# Altium schematic sheet 5V-REG.SchDoc
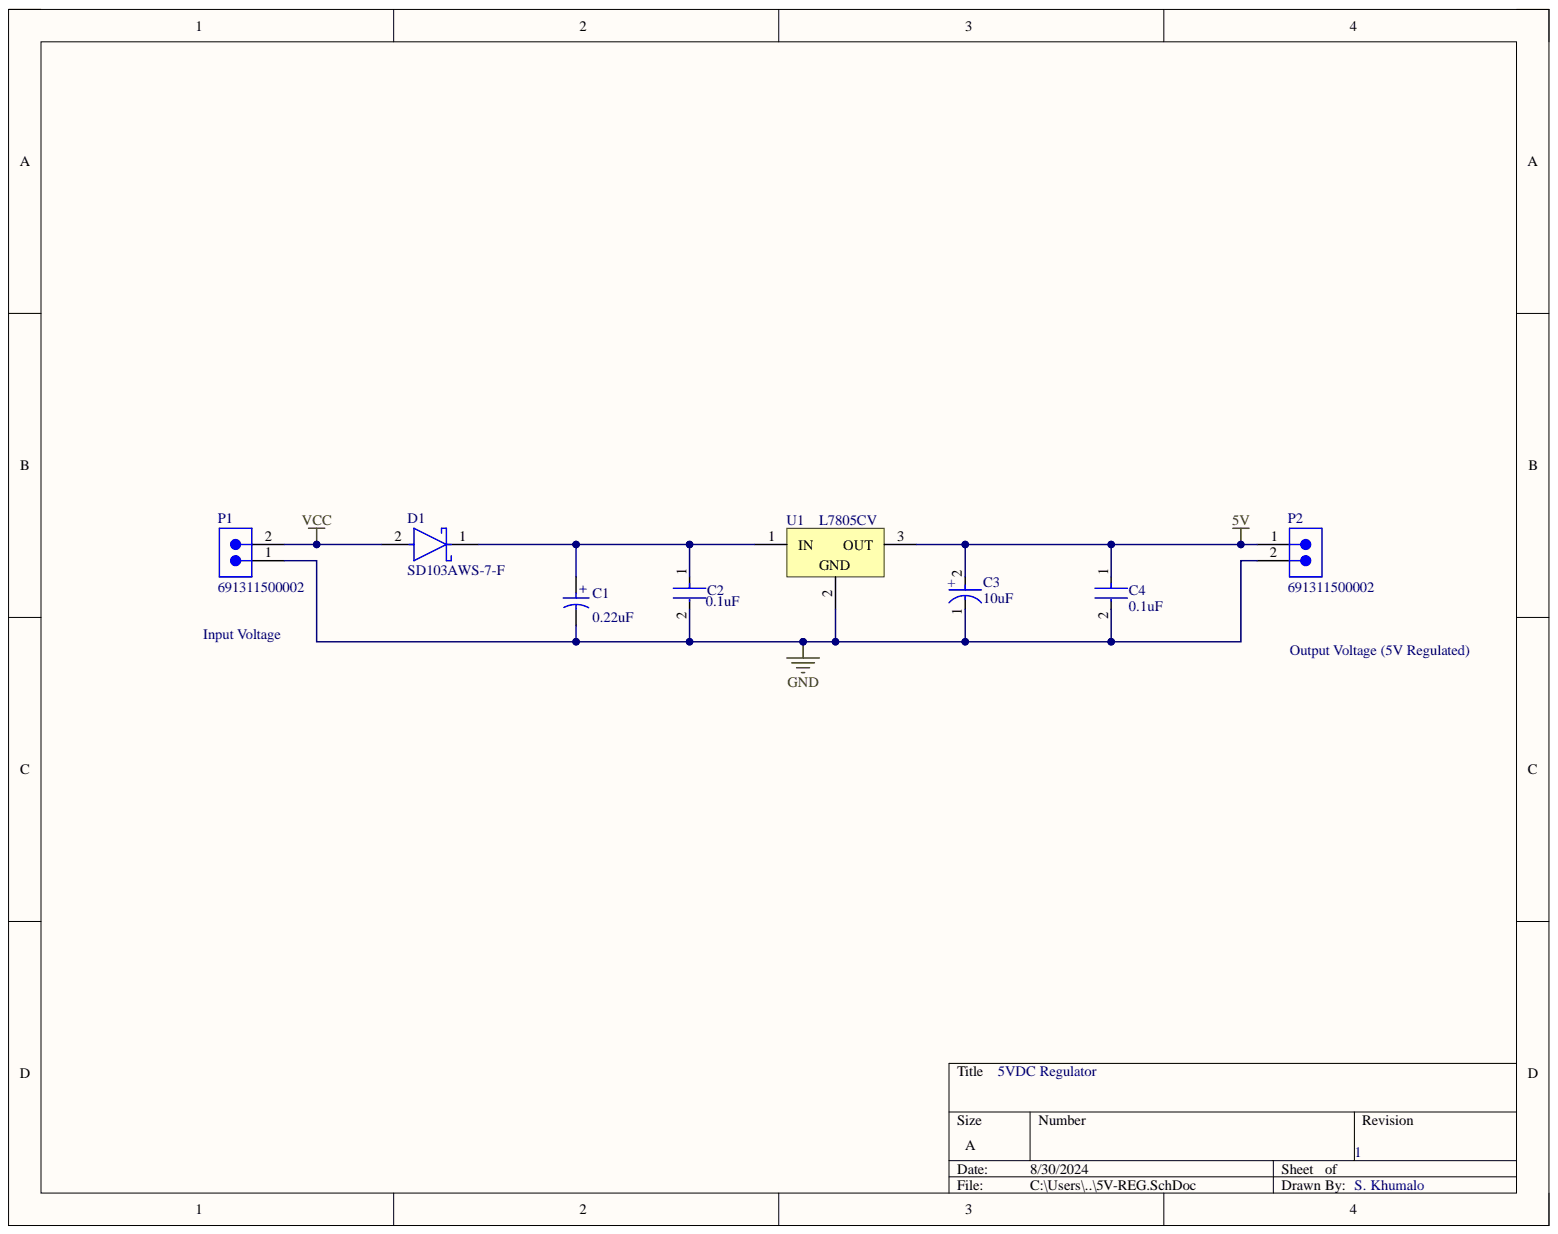
[diagram p0: 5V-REG.SchDoc]

## Parts
C1  0.22uF  [WCAP-ATG8_D5H11]
C2  0.1uF  [FP-RDE0P1-MFG]
C3  10uF  [FP-RAD-TH-D_5_0_5-L_11_1-MFG]
C4  0.1uF  [FP-RDE0P1-MFG]
D1  SD103AWS-7-F  [FP-SOD323-MFG]
P1  691311500002  [691311500002]
P2  691311500002  [691311500002]
U1  L7805CV  [TO220]

## Nets
5V: C3.2 C4.1 P2.1 U1.3
GND: C1.2 C2.2 C3.1 C4.2 P1.1 P2.2 U1.2
VCC: D1.2 P1.2
NetC1_1: C1.1 C2.1 D1.1 U1.1

## Library data of the parts
C1 = CMP-03913-54007281-1: 860010772002 WCAP-ATG8 Aluminum Electrolytic Capacitors; Capacitance: 220nF; Dissipation Factor: 9%; Leakage Current: 3µA; Life (Hours): 2000hours; Pitch: 2mm; Polarity: Polar; Ripple Current: 4mA; Tolerance: 20%; Voltage Rating: 63V; Case/Package: Radial; Component Kind: Standard; Diameter: 5mm; Height: 11mm; Height - Seated (Max): 12.4968mm; Lead Pitch: 2.0066mm; Length: 11mm; Library Name: Altium Content Vault; Max Operating Temperature: 85°C; Min Operating Temperature: -40°C; Mount: Through Hole; NexarPartId: 54007281; Packaging: Tape & Box (TB); REACH SVHC: Unknown; RoHS: Compliant; Voltage Rating (DC): 63V
C2 C4 = CMP-06035-036375-1: Ceramic Capacitor 100nF ±10% 25V X7R Radial; Lead Diameter: 0.5mm; Capacitance: 100nF; Case/Package: Radial; Component Kind: Standard; Dielectric: X7R; Height: 3.5mm; Height - Seated (Max): 0.236inch; Lead Free: Lead Free; Lead Pitch: 0.098inch; Length: 0.197inch; Library Name: Altium Content Vault; Max Operating Temperature: 125°C; Min Operating Temperature: -55°C; Mount: Through Hole; Package Quantity: 500; Packaging: Bulk; Resistor Type: Ceramic; Termination: Radial; Tolerance: 10%; Voltage Rating: 25V; Voltage Rating (DC): 25V; Width: 0.098inch
C3 = CMP-05427-001295-1: Aluminum Electrolytic Capacitors (Radial Lead Type) 10uF ±20% 50V; Impedance: 340mR; Lead Diameter: 0.5mm; Life (Hours): 5000Hour; Ripple Current (AC): 250mA; Capacitance: 10uF; Case/Package: Radial; Component Kind: Standard; Construction: RADIAL; Diameter: 5mm; Height: 11mm; Lead Pitch: 2mm; Library Name: Altium Content Vault; Max Operating Temperature: 105°C; Min Operating Temperature: -40°C; REACH SVHC: Yes; RoHS Compliant: Yes; Series: FR Radial; Termination: Radial; Tolerance: 20%; Voltage: 50V; Voltage Rating: 50V
D1 = CMP-12790-000048-1: Diode Schottky 40V 350mA (DC) Surface Mount SOD-323; Manufacturer: Diodes Inc.; Automotive Grade: Grade 1; Capacitance: 35pF; Max Junction Temp: +125°C; Max Surge Forward Current: 1.5A; Peak Reverse Current: 5uA; Standoff Height: 0.05mm; Value: 35pF; Automotive: Yes; Category: Diode; Component Kind: Standard; ComponentLink2Description: Datasheet; ComponentLink2URL: https://www.diodes.com/assets/Datasheets/ds30101.pdf; Device Class L1: Discrete Semiconductors; Device Class L2: Diodes; Device Class L3: Schottky Diodes; Digikey Description: DIODE SCHOTTKY 40V 350MA SOD323; Footprint Url: https://www.diodes.com/assets/Package-Files/SOD323.pdf; Forward Current: 350mA; Height: 1.2mm; Ipc Land Pattern Name: SOD250130X110-2; Lead Free: Yes; Library Name: Altium Content Vault; Manufacturer Part Number: SD103AWS-7-F; Max Repetitive Reverse Voltage: 40V; Mouser Description: Schottky Diodes & Rectifiers 40V 200mW; Octopart: https://octopart.com/sd103aws-7-f-diodes+inc.-55389602; Package: SOD323; Reverse Voltage: 40V; RoHS: TRUE; Temperature: -65°C to +125°C; Temperature Range High: +125°C; Temperature Range Low: -65°C
P1 P2 = CMP-1502-02123-2: NexarPartId: 8917741; Current: 20A; Gender: Male; Number of Contacts: 2; Number of Levels: 1; Pitch: 5.08mm; Voltage: 300V; Color: Green; Component Kind: Standard; Contact Material: Brass; Contact Plating: Tin; Height: 12mm; Lead Pitch: 5.08mm; Length: 8.5mm; Library Name: Altium Content Vault; Mount: Through Hole; Number of Pins: 2; Number of Positions Per Level: 2; Number of Rows: 1; Orientation: Vertical; Packaging: Box; REACH SVHC: Unknown; RoHS: Compliant; Termination: Solder; Width: 10.16mm
U1 = CMP-0244-00483-1: Positive Voltage Regulator, 5V, 3-Pin TO-220; Component Kind: Standard; ComponentLink1Description: Manufacturer URL; ComponentLink1URL: http://www.st.com; ComponentLink2Description: Datasheet; ComponentLink2URL: http://www.st.com/internet/com/TECHNICAL_RESOURCES/TECHNICAL_LITERATURE/DATASHEET/CD00000444.pdf; ComponentLink3Description: Package Specification; ComponentLink3URL: http://www.st.com/internet/com/TECHNICAL_RESOURCES/TECHNICAL_LITERATURE/PACKAGE_INFORMATION/CD00004649.pdf; DatasheetVersion: 16/11/2011; Library Name: Altium Content Vault; PackageDescription: TO, Thru-Hole, Vertical, Heatsink Mounted; 3 In-Line Leads; Pitch 2.54 mm; PackageReference: TO220; PackageVersion: ver3.0
